# Altium schematic sheet yandex_test.SchDoc
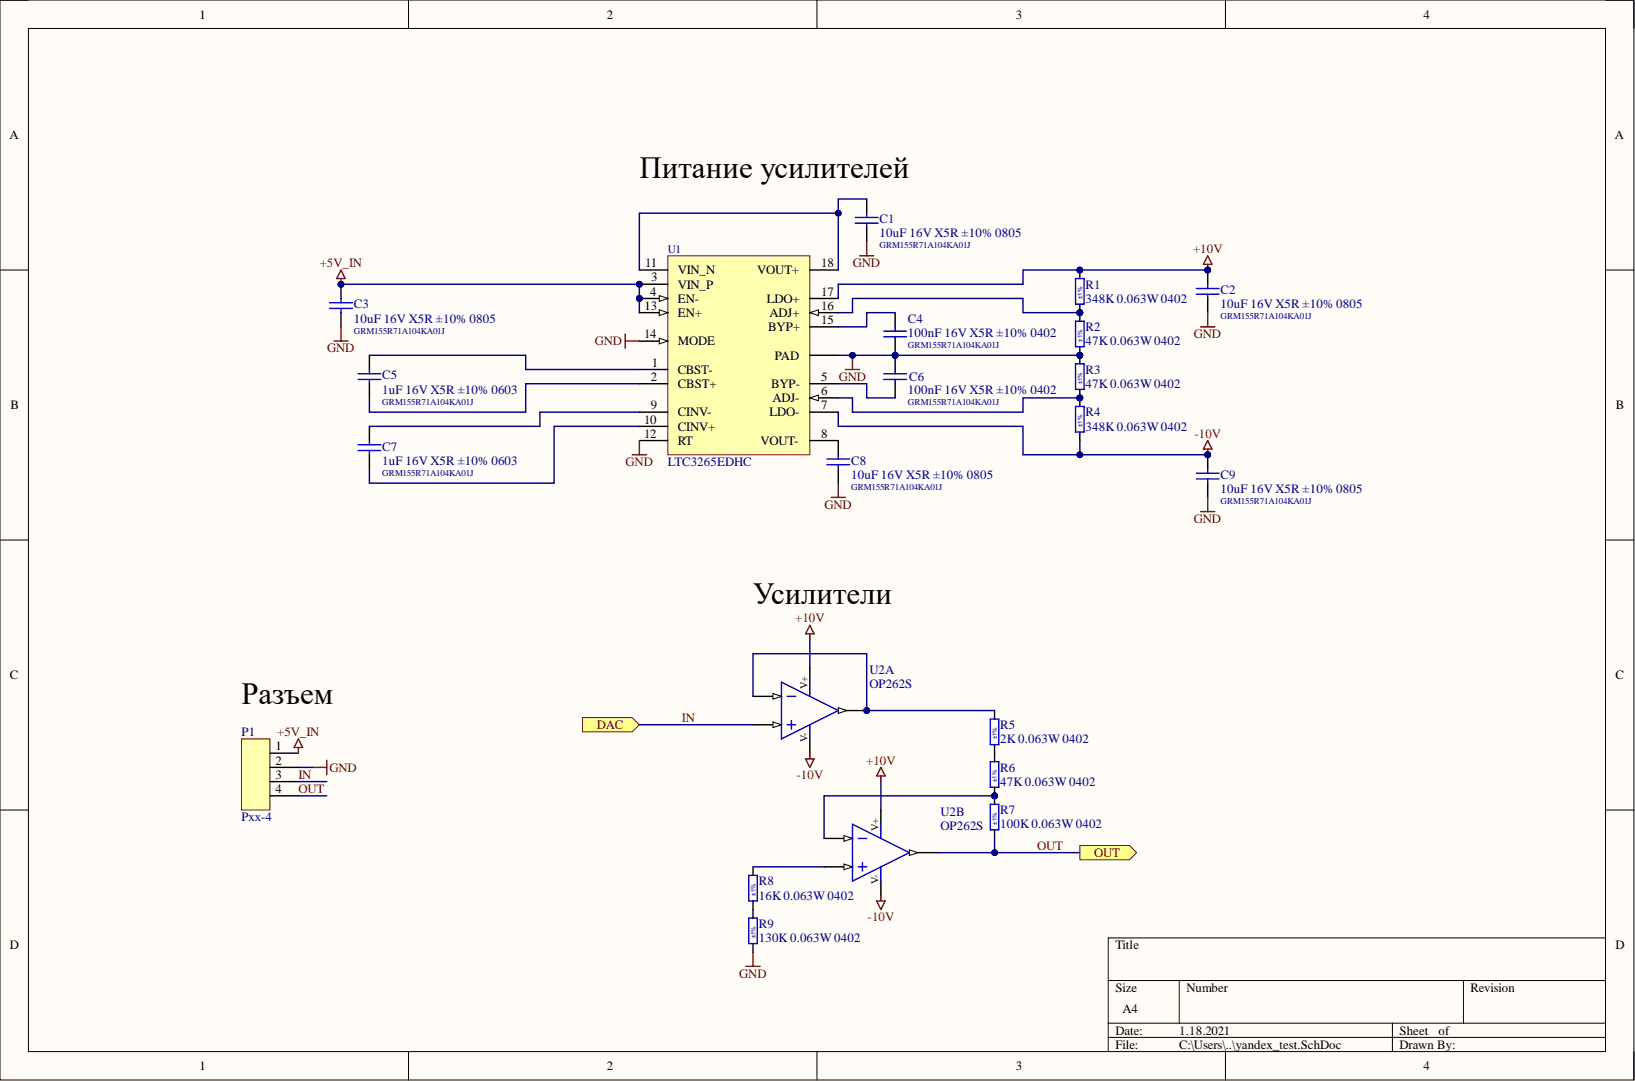
[diagram p0: yandex_test.SchDoc]

## Parts
C1  Capacitance: 10uF  Name: GRM155R71A104KA01J  [0805]  (hidden comment: 10uF 16V X5R ±10% 0805)
C2  Capacitance: 10uF  Name: GRM155R71A104KA01J  [0805]  (hidden comment: 10uF 16V X5R ±10% 0805)
C3  Capacitance: 10uF  Name: GRM155R71A104KA01J  [0805]  (hidden comment: 10uF 16V X5R ±10% 0805)
C4  Capacitance: 100nF  Name: GRM155R71A104KA01J  [0402]  (hidden comment: 100nF 16V X5R ±10% 0402)
C5  Capacitance: 1uF  Name: GRM155R71A104KA01J  Voltage: 16V  [0603]  (hidden comment: 1uF 16V X5R ±10% 0603)
C6  Capacitance: 100nF  Name: GRM155R71A104KA01J  [0402]  (hidden comment: 100nF 16V X5R ±10% 0402)
C7  Capacitance: 1uF  Name: GRM155R71A104KA01J  Voltage: 16V  [0603]  (hidden comment: 1uF 16V X5R ±10% 0603)
C8  Capacitance: 10uF  Name: GRM155R71A104KA01J  [0805]  (hidden comment: 10uF 16V X5R ±10% 0805)
C9  Capacitance: 10uF  Name: GRM155R71A104KA01J  [0805]  (hidden comment: 10uF 16V X5R ±10% 0805)
P1  Pxx-4  [PBD4_2.54]
R1  Resistance: 348K  Tolerance: ±1%  [0402]  (hidden comment: 348K 0.063W 0402)
R2  Resistance: 47K  Tolerance: ±1%  [0402]  (hidden comment: 47K 0.063W 0402)
R3  Resistance: 47K  Tolerance: ±1%  [0402]  (hidden comment: 47K 0.063W 0402)
R4  Resistance: 348K  Tolerance: ±1%  [0402]  (hidden comment: 348K 0.063W 0402)
R5  Power: 0.063W  Resistance: 2K  Tolerance: ±1%  [0402]  (hidden comment: 2K 0.063W 0402)
R6  Power: 0.063W  Resistance: 47K  Tolerance: ±1%  [0402]  (hidden comment: 47K 0.063W 0402)
R7  Resistance: 100K  Tolerance: ±1%  [0402]  (hidden comment: 100K 0.063W 0402)
R8  Resistance: 16K  Tolerance: ±1%  [0402]  (hidden comment: 16K 0.063W 0402)
R9  Resistance: 130K  Tolerance: ±1%  [0402]  (hidden comment: 130K 0.063W 0402)
U1  LTC3265EDHC
U2A  OP262S  [UFLGA6]
U2B  OP262S  [UFLGA6]

## Ports
DAC, OUT

## Nets
+5V_IN: C3.1 P1.1 U1.3(VIN_P) U1.4(EN-) U1.13(EN+)
+10V: C2.1 R1.2 U1.17(LDO+) U2A.6 U2B.6
-10V: C9.1 R4.1 U1.7(LDO-) U2A.2 U2B.2
GND: C1.2 C2.2 C3.2 C4.2 C6.1 C8.2 C9.2 P1.2 R2.1 R3.2 R9.1 U1.12(RT) U1.14(MODE) U1.19(PAD)
IN: P1.3 U2A.3 ; port DAC
OUT: P1.4 R7.1 U2B.1 ; port OUT
NetC1_1: C1.1 U1.11(VIN_N) U1.18(VOUT+)
NetC4_1: C4.1 U1.15(BYP+)
NetC5_1: C5.1 U1.1(CBST-)
NetC5_2: C5.2 U1.2(CBST+)
NetC6_2: C6.2 U1.5(BYP-)
NetC7_1: C7.1 U1.9(CINV-)
NetC7_2: C7.2 U1.10(CINV+)
NetC8_1: C8.1 U1.8(VOUT-)
NetR1_1: R1.1 R2.2 U1.16(ADJ+)
NetR3_1: R3.1 R4.2 U1.6(ADJ-)
NetR5_1: R5.1 R6.2
NetR5_2: R5.2 U2A.1 U2A.4
NetR6_1: R6.1 R7.2 U2B.4
NetR8_1: R8.1 R9.2
NetR8_2: R8.2 U2B.3

## Library data of the parts
C1-C3 C8 C9 = Capacitor ceramic: Ceramic capacitor; Manufacturer: Murata; BOMString: 10uF 16V ±10% 805; Component Kind: Standard; Dielectric: X5R; Library Name: Passive.SchLib; Tolerance: ±10%; Voltage: 16V
C4 C6 = Capacitor ceramic: Ceramic capacitor; Manufacturer: Murata; BOMString: 100nF 16V ±10% 402; Component Kind: Standard; Dielectric: X5R; Library Name: Passive.SchLib; Tolerance: ±10%; Voltage: 16V
C5 C7 = Capacitor ceramic: Ceramic capacitor; Manufacturer: Murata; BOMString: 1uF 16V ±10% 603; Component Kind: Standard; Dielectric: X5R; Library Name: Passive.SchLib; Tolerance: ±10%
R1 R4 = Resistor: Thin Film Chip Resistor; Manufacturer: Samsung; BOMString: 348K ±1% 0.063W 402; Name: RC1005J102CS; Component Kind: Standard; Library Name: Passive.SchLib; Power: 0.063W
R2 R3 R6 = Resistor: Thin Film Chip Resistor; Manufacturer: Samsung; BOMString: 47K ±1% 0.063W 402; Name: RC1005J102CS; Component Kind: Standard; Library Name: Passive.SchLib
  R2 R3: Power: 0.063W
R5 = Resistor: Thin Film Chip Resistor; Manufacturer: Samsung; BOMString: 2K ±1% 0.063W 402; Name: RC1005J102CS; Component Kind: Standard; Library Name: Passive.SchLib
R7 = Resistor: Thin Film Chip Resistor; Manufacturer: Samsung; BOMString: 100K ±1% 0.063W 402; Name: RC1005J102CS; Component Kind: Standard; Library Name: Passive.SchLib; Power: 0.063W
R8 = Resistor: Thin Film Chip Resistor; Manufacturer: Samsung; BOMString: 16K ±1% 0.063W 402; Name: RC1005J102CS; Component Kind: Standard; Library Name: Passive.SchLib; Power: 0.063W
R9 = Resistor: Thin Film Chip Resistor; Manufacturer: Samsung; BOMString: 130K ±1% 0.063W 402; Name: RC1005J102CS; Component Kind: Standard; Library Name: Passive.SchLib; Power: 0.063W
U1 = LTC3265: Low Noise Dual Supply  with Boost and Inverting  Charge Pumps; Component Kind: Standard; Library Name: IC_DCDC.SchLib; Name: LTC3265EDHC
U2A U2B = OPAMP: Rail to rail opamp; Component Kind: Standard; HelpURL: https://www.mouser.com/datasheet/2/268/21733h-26779.pdf; Library Name: IC_OPAMP_COMP.SchLib; Price(1): 0.64; Price(100): 0.40170; Supplier: https://www.chipdip.ru/product/mcp6001rt-i-ot-microchip
P1 = Pxx-4: Component Kind: Standard; Library Name: CONN_PLx.SchLib
